# Altium schematic sheet Misc. Connections.SchDoc
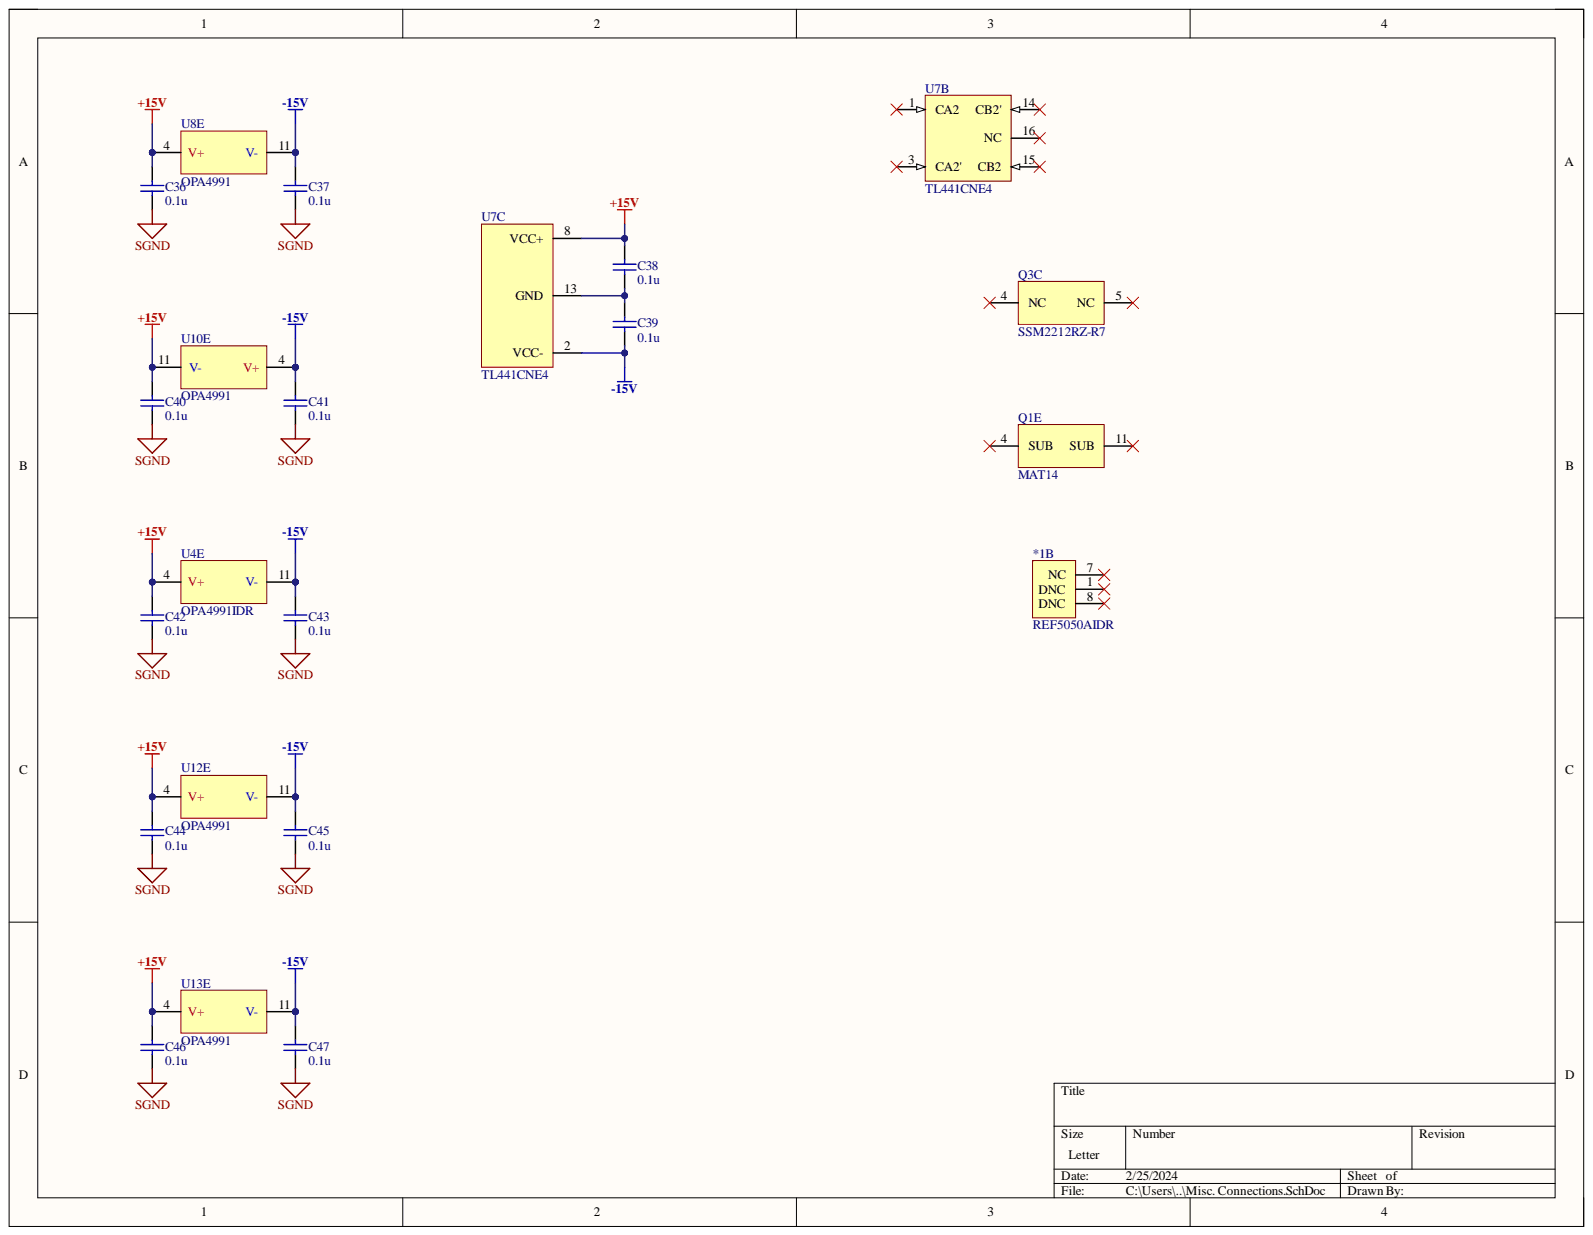
[diagram p0: Misc. Connections.SchDoc]

## Parts
*1  REF5050AIDR  [D0008A_M]  (part B)
C36  value 0.1u  (hidden comment: Capacitor)
C37  value 0.1u  (hidden comment: Capacitor)
C38  value 0.1u  (hidden comment: Capacitor)
C39  value 0.1u  (hidden comment: Capacitor)
C40  value 0.1u  (hidden comment: Capacitor)
C41  value 0.1u  (hidden comment: Capacitor)
C42  value 0.1u  (hidden comment: Capacitor)
C43  value 0.1u  (hidden comment: Capacitor)
C44  value 0.1u  (hidden comment: Capacitor)
C45  value 0.1u  (hidden comment: Capacitor)
C46  value 0.1u  (hidden comment: Capacitor)
C47  value 0.1u  (hidden comment: Capacitor)
Q1  MAT14  [FP-R-14-IPC_B]  (part E)
Q3  SSM2212RZ-R7  [FP-R-8-IPC_B]  (part C)
U4  OPA4991IDR  [FP-D0014A-IPC_B]  (part E)
U7  TL441CNE4  [TI-N16]  (parts B,C)
U8  OPA4991  [FP-D0014A-IPC_B]  (part E)
U10  OPA4991  [FP-D0014A-IPC_B]  (part E)
U12  OPA4991  [FP-D0014A-IPC_B]  (part E)
U13  OPA4991  [FP-D0014A-IPC_B]  (part E)

## Nets
+15V: C36.2 C38.2 C40.2 C42.2 C44.2 C46.2 U4.4(V+) U7.8(VCC+) U8.4(V+) U10.11(V-) U12.4(V+) U13.4(V+)
-15V: C37.2 C39.1 C41.2 C43.2 C45.2 C47.2 U4.11(V-) U7.2(VCC-) U8.11(V-) U10.4(V+) U12.11(V-) U13.11(V-)
SGND: C36.1 C37.1 C40.1 C41.1 C42.1 C43.1 C44.1 C45.1 C46.1 C47.1
NetC38_1: C38.1 C39.2 U7.13(GND)

Not connected: *1 1(DNC) 7(NC) 8(DNC); Q1 4(SUB) 11(SUB); Q3 4(NC) 5(NC); U7 1(CA2) 3(CA2') 14(CB2') 15(CB2) 16(NC)

## Library data of the parts
*1 = REF5050AIDR: Three Terminal Voltage Reference, 1 Output, 5V, Trim/Adjustable, PDSO8; Manufacturer: Texas Instruments; Channels: 1; Max Output Voltage: 5.005V; Max Supply Voltage: 18V; Min Output Voltage: 4.995V; Min Supply Voltage: 2.7V; Package Body Material: Plastic; Package Equivalence Code: SOP8,.25; Qualification Status: Not Qualified; Temp Coef of Voltage-Max: 3ppm/°C; Trim/Adjustable Output: Yes; Voltage Tolerance-Max: 0.1%; Case/Package: SOP; Category: Voltage References; Component Kind: Standard; ComponentLink1Description: https://www.ti.com:443/lit/ds/symlink/ref5010.pdf?src-supplier=IHS+Markit; ComponentLink1URL: https://www.ti.com:443/lit/ds/symlink/ref5010.pdf?src-supplier=IHS+Markit; ComponentLink2Description: https://datasheet.ciiva.com/3236/ref5050-3236312.pdf?src-supplier=Avnet; ComponentLink2URL: https://datasheet.ciiva.com/3236/ref5050-3236312.pdf?src-supplier=Avnet; ComponentLink3Description: https://datasheet.ciiva.com/2012/ref5020-2012761.pdf?src-supplier=Digikey; ComponentLink3URL: https://datasheet.ciiva.com/2012/ref5020-2012761.pdf?src-supplier=Digikey; Height - Seated (Max): 1.75mm; JESD-30 Code: R-PDSO-G8; JESD-609 Code: e4; Length: 4.9mm; Manufacturer Part Number: REF5050AIDR; Max Operating Temperature: 125°C; Min Operating Temperature: -40°C; Moisture Sensitivity Level: 2; Nominal Output Voltage: 5V; Number of Outputs: 1; Package Shape: Rectangular; Package Style: SMALL OUTLINEMeter; Peak Reflow Temperature (Cel): 260°C; Pins: 8; Pricing: 1=3.52, 10=3.17, 50=3.17, 100=2.59, 250=2.46, 500=2.21, 1000=1.86, 2500=1.77, 5000=1.7, 10000=1.77 (USD); Reach SVHC Compliant: Yes; RoHS: Yes; RoHS Compliant: Yes; Stock: 15005; Supplier: Mouser; Supplier Part Number: 595-REF5050AIDR; Surface Mount: Yes; Temperature Grade: AUTOMOTIVE; Terminal Finish: Nickel; Terminal Form: Gull Wing; Terminal Pitch: 1.27mm; Terminal Position: DUAL; Throw Configuration: THREE TERMINAL VOLTAGE REFERENCE; Width: 3.9mm; Library Name: DRC.SchLib
C36-C47 = Capacitor: SimulationGenericComponent: True; Component Kind: Standard; Description: Capacitor; Library Name: Simulation Generic Components.IntLib; Simulation: C_Capacitor_Default
Q1 = MAT14ARZ: TRANS 4NPN 40V 0.03A 14SO; Collector Emitter Saturation Voltage: 60mV; Frequency: 300MHz; Max Collector Current: 30mA; Max Frequency: 1kHz; Number of Elements: 4; Polarity: NPN; Case/Package: SOIC; Collector Base Voltage (VCBO): 40V; Collector Emitter Breakdown Voltage: 40V; Collector Emitter Voltage (VCEO): 40V; Collector-emitter Voltage-Max: 60mV; Component Kind: Standard; Height: 1.5mm; Lead Free: Contains Lead; Length: 8.75mm; Max Operating Temperature: 85°C; Min Operating Temperature: -40°C; Mount: Surface Mount; Number of Pins: 14; Package Quantity: 56; Packaging: Rail/Tube; Radiation Hardening: No; REACH SVHC: No SVHC; RoHS Compliant: Yes; Series: MAT14; Transition Frequency: 300MHz; Width: 4mm; Library Name: DRC.SchLib
Q3 = SSM2212: TRANS 2NPN 40V 0.02A 8SOIC; Collector Emitter Breakdown Voltage: 45V; Collector-emitter Voltage-Max: 800mV; Element Configuration: Dual; Frequency: 200MHz; Max Collector Current: 20mA; Max Power Dissipation: 500mW; Number of Elements: 2; Polarity: NPN; Transition Frequency: 450MHz; Case/Package: SOIC; Collector Base Voltage (VCBO): 40V; Collector Emitter Voltage (VCEO): 40V; Component Kind: Standard; Contact Plating: Tin; Lead Free: Contains Lead; Max Breakdown Voltage: 40V; Max Operating Temperature: 85°C; Min Operating Temperature: -40°C; Mount: Surface Mount; Number of Pins: 8; Package Quantity: 1000; Packaging: Tape and Reel; Radiation Hardening: No; RoHS Compliant: Yes; Series: SSM2212; Library Name: DRC.SchLib
U4 U8 U10 U12 U13 = OPA4991IDR: 40-V, DUAL 4.5MHZ, RAIL-TO-RAIL; Component Kind: Standard; Library Name: DRC.SchLib
U7 = TL441CNE4: Logarithmic Amplifier, 0 to 70 degC, 16-pin DIP (N16), Pb-Free (RoHS); Manufacturer: Texas Instruments; Iq(Max)(mA): 23; Code_JEDEC: MS-001; Component Kind: Standard; ComponentLink1Description: Manufacturer URL; ComponentLink1URL: http://www.ti.com/; ComponentLink2Description: Datasheet; ComponentLink2URL: http://www.ti.com/general/docs/lit/getliterature.tsp?genericPartNumber=TL441&fileType=pdf; ComponentLink3Description: Package Specification; ComponentLink3URL: http://www.ti.com/litv/pdf/mpdi002c; DatasheetVersion: SLVS328; Eco-Plan: Pb-Free (RoHS); Mounting Technology: Through Hole; PackageDescription: 16-Pin Plastic In-Line, Body 19.305 x 6.35 mm, Pitch 2.54 mm; PackageReference: N16; PackageVersion: 4040049/E, 12/2002; PartNumber: TL441CNE4; SubFamily: Logarithmic Amplifier; Library Name: DRC.SchLib
